# Altium schematic sheet BMS.SchDoc
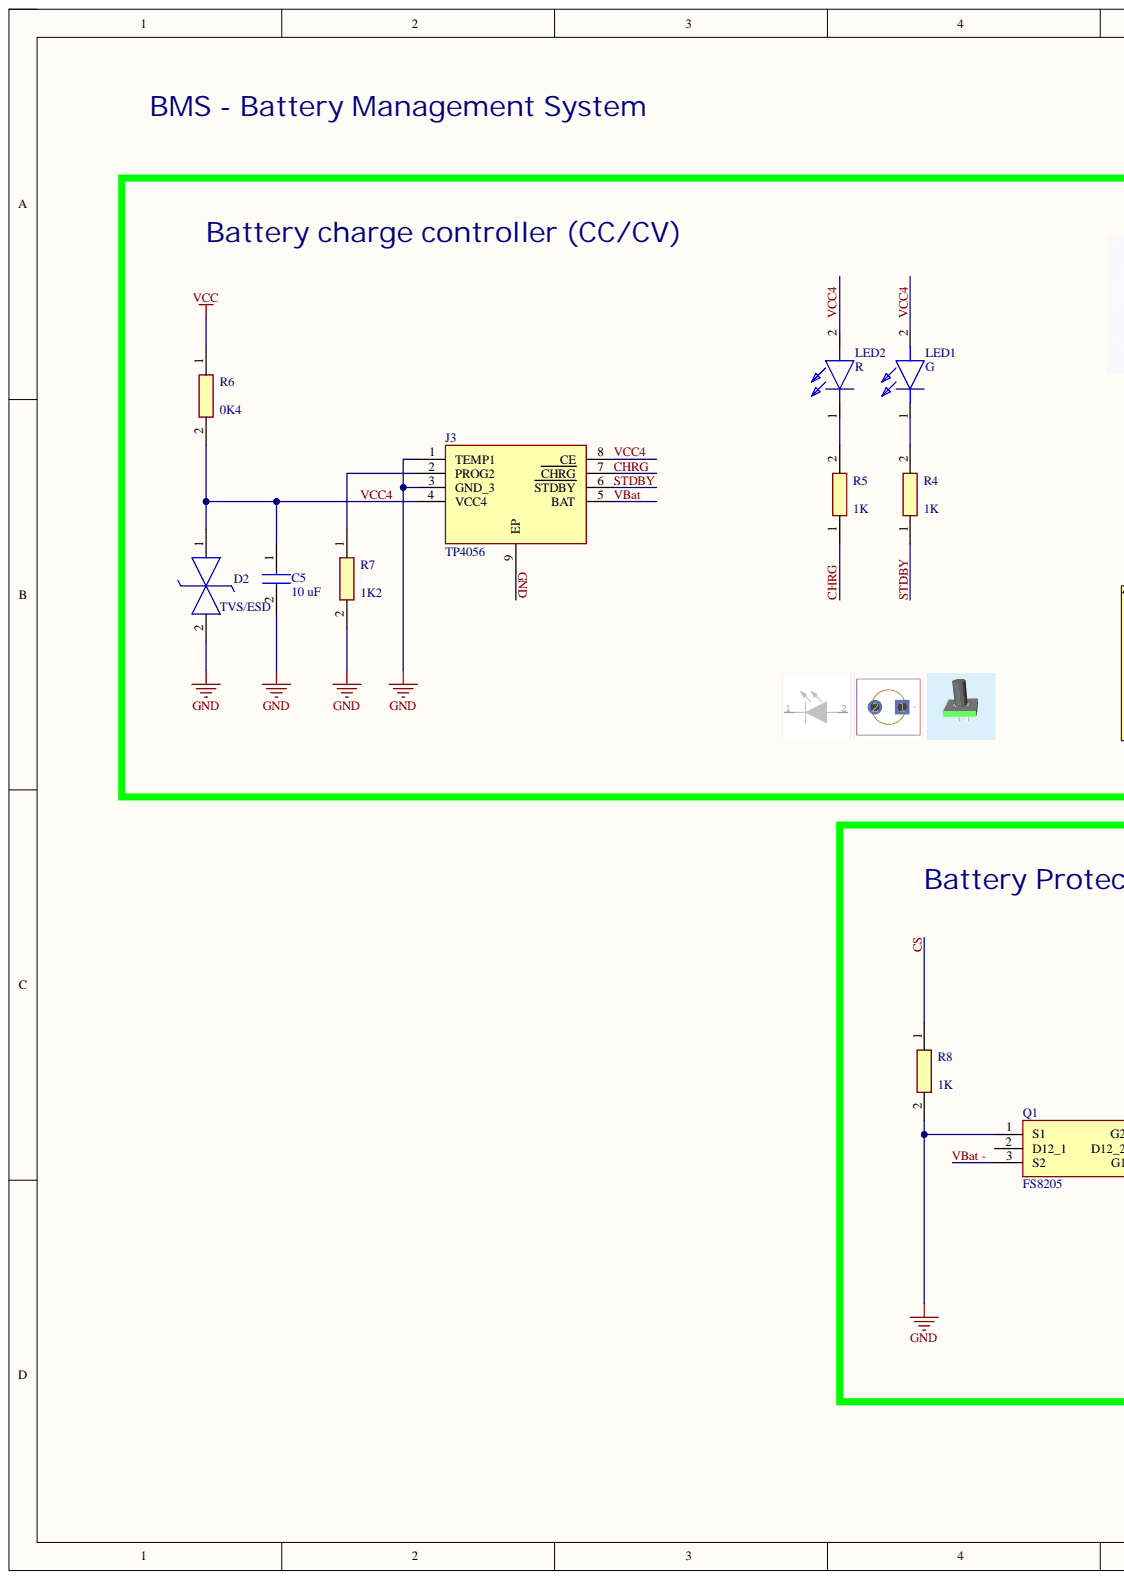
[diagram p0: BMS.SchDoc, left]
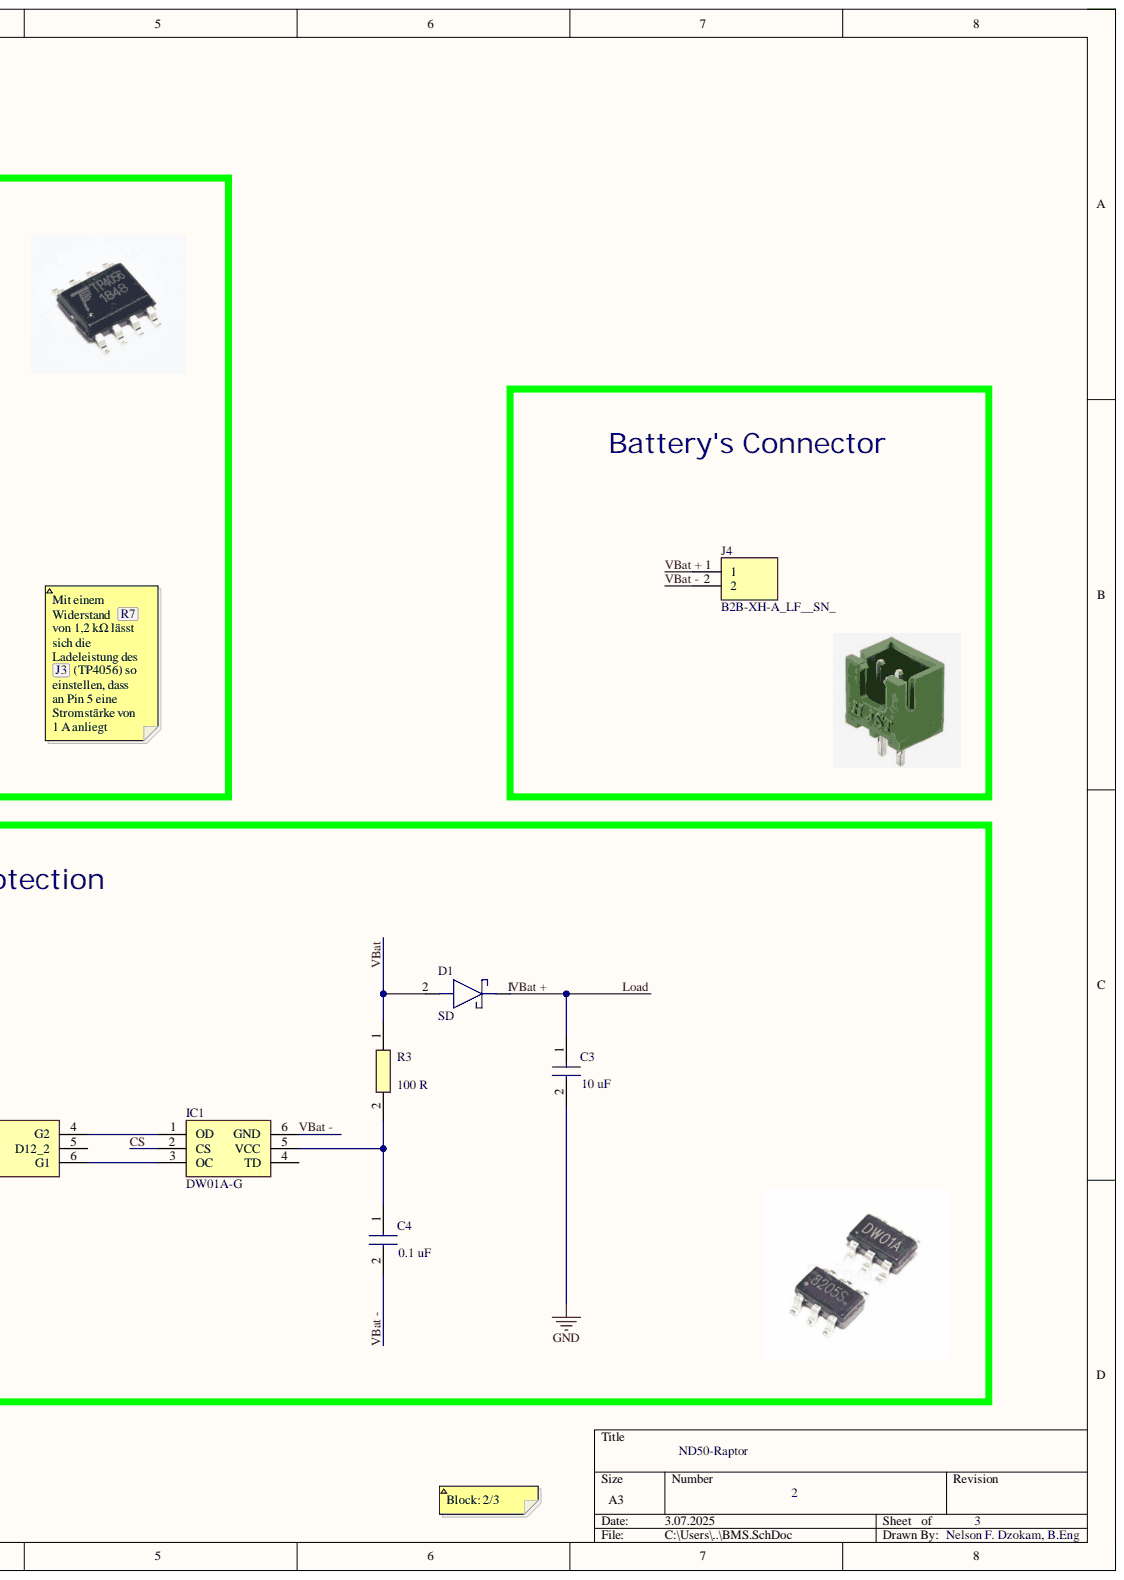
[diagram p1: BMS.SchDoc, right]

## Parts
C3  10 uF  [C0805]
C4  0.1 uF  [C0805]
C5  10 uF  [C0805]
D1  SD  [SODFL4725X110N]
D2  TVS/ESD  [SOD2512X110N]
IC1  DW01A-G  [SOT95P280X135-6N]
J3  TP4056  [SOIC127P600X175-9N]
J4  B2B-XH-A_LF__SN_  [SHDR2W64P0X250_1X2_740X575X700P]
LED1  G  [TLHG4400]
LED2  R  [TLHR4400]
Q1  FS8205  [FS8205]
R3  100 R  [RESC2012X60N]
R4  1K  [RESC2012X60N]
R5  1K  [RESC2012X60N]
R6  0K4  [RESC2012X60N]
R7  1K2  [RESC2012X70N]
R8  1K  [RESC2012X60N]

## Nets
VCC: R6.1
CHRG: J3.7(CHRG) R5.1
CS: IC1.2(CS) R8.1
GND: C3.2 C5.2 D2.2 J3.1(TEMP1) J3.3(GND_3) J3.9(EP) Q1.1(S1) R7.2 R8.2
Load: C3.1 D1.1 J4.1
STDBY: J3.6(STDBY) R4.1
VBat: D1.2 J3.5(BAT) R3.1
VBat -: C4.2 IC1.6(GND) J4.2 Q1.3(S2)
VCC4: C5.1 D2.1 J3.4(VCC4) J3.8(CE) LED1.2 LED2.2 R6.2
NetC4_1: C4.1 IC1.5(VCC) R3.2
NetIC1_1: IC1.1(OD) Q1.4(G2)
NetIC1_3: IC1.3(OC) Q1.6(G1)
NetJ3_2: J3.2(PROG2) R7.1
NetLED1_1: LED1.1 R4.2
NetLED2_1: LED2.1 R5.2

Not connected: IC1 4(TD); Q1 2(D12_1) 5(D12_2)

## Library data of the parts
C3 C5 = C0805C106K7PACTU: Capacitor  C0805C106K7PACTU; Manufacturer_Name: KEMET; Component Kind: Standard; Datasheet Link: https://content.kemet.com/datasheets/KEM_C1006_X5R_SMD.pdf; Height: 1.1mm; Library Name: SamacSys.SchLib; Manufacturer_Part_Number: C0805C106K7PACTU; Mouser Part Number: 80-C0805C106K7P; Mouser Price/Stock: https://www.mouser.com/Search/Refine.aspx?Keyword=80-C0805C106K7P
C4 = C0805C106K7PACTU: Capacitor  08056D104KAT2A; Manufacturer_Name: KEMET; Component Kind: Standard; Datasheet Link: https://content.kemet.com/datasheets/KEM_C1006_X5R_SMD.pdf; Height: 1.1mm; Library Name: SamacSys.SchLib; Manufacturer_Part_Number: C0805C106K7PACTU; Mouser Part Number: 80-C0805C106K7P; Mouser Price/Stock: https://www.mouser.com/Search/Refine.aspx?Keyword=80-C0805C106K7P
D1 = PMEG3020EP-QX: Schottky Diode  PMEG3020EP-QX; Manufacturer_Name: Nexperia; Component Kind: Standard; Datasheet Link: https://assets.nexperia.com/documents/data-sheet/PMEG3020EP-Q.pdf; Height: 1.1mm; Library Name: SamacSys.SchLib; Manufacturer_Part_Number: PMEG3020EP-QX; Mouser Part Number: 771-PMEG3020EP-QX; Mouser Price/Stock: https://www.mouser.co.uk/ProductDetail/Nexperia/PMEG3020EP-QX?qs=doiCPypUmgFzhg9CfUI%2Fgg%3D%3D
D2 = PESD5V0S1BAF: Diode ESD (Bi-directional) PESD5V0S1BAF; Manufacturer_Name: Nexperia; Arrow Part Number: PESD5V0S1BAF; Arrow Price/Stock: https://www.arrow.com/en/products/pesd5v0s1baf/nexperia?utm_currency=USD&region=nac; Component Kind: Standard; Datasheet Link: https://assets.nexperia.com/documents/data-sheet/PESD5V0S1BA.pdf; Height: 1.1mm; Manufacturer_Part_Number: PESD5V0S1BAF; Mouser Part Number: 771-PESD5V0S1BAF; Mouser Price/Stock: https://www.mouser.co.uk/ProductDetail/Nexperia/PESD5V0S1BAF?qs=45Avz0nZxhtk8Fj2r0tKWQ%3D%3D
IC1 = DW01A-G: Integrated Circuit; Manufacturer_Name: Fortune Semiconductor Corporation; Component Kind: Standard; Datasheet Link: http://acoptex.com/uploads/DW01A.pdf; Height: 1.35mm; Manufacturer_Part_Number: DW01A-G
J3 = TP4056: Connector; Manufacturer_Name: NanJing Top Power; Component Kind: Standard; Datasheet Link: https://dlnmh9ip6v2uc.cloudfront.net/datasheets/Prototyping/TP4056.pdf; Height: 1.75mm; Manufacturer_Part_Number: TP4056
J4 = B2B-XH-A_LF__SN_: Connector                                B2B-XH-A_LF__SN_; Manufacturer_Name: JST (JAPAN SOLDERLESS TERMINALS); Manufacturer_Part_Number: B2B-XH-A(LF)(SN); Arrow Part Number: B2B-XH-A(LF)(SN); Arrow Price/Stock: https://www.arrow.com/en/products/b2b-xh-a-lf-sn/jst-manufacturing?utm_currency=USD&region=europe; Component Kind: Standard; Datasheet Link: http://www.jst-mfg.com/product/pdf/eng/eXH.pdf; Height: 7mm; Library Name: SamacSys.SchLib; Mouser Part Number: 306-B2BXHALFSNP; Mouser Price/Stock: https://www.mouser.co.uk/ProductDetail/JST-Commercial/B2B-XH-ALFSN?qs=cdbOS8ANM9DdcSn9qRmfCw%3D%3D
LED1 = TLHG4400: LED                              TLHG4400                      https://www.mouser.de/ProductDetail/Vishay-Semiconductors/TLHG4400?qs=JrSo%252BAIZiDFQF4915wuwPg%3D%3D; Manufacturer_Name: Vishay; Arrow Part Number: TLHG4400; Arrow Price/Stock: https://www.arrow.com/en/products/tlhg4400/vishay?region=europe; Component Kind: Standard; Datasheet Link: https://www.vishay.com/docs/83006/tlhg440.pdf; Height: 6.1mm; Manufacturer_Part_Number: TLHG4400; Mouser Part Number: 78-TLHG4400; Mouser Price/Stock: https://www.mouser.co.uk/ProductDetail/Vishay-Semiconductors/TLHG4400?qs=JrSo%252BAIZiDFQF4915wuwPg%3D%3D
LED2 = TLHR4400: LED                                    TLHR4400                                   https://www.mouser.de/ProductDetail/Vishay-Semiconductors/TLHR4400?qs=W6eMvlWxUPxv3guYUdjVjA%3D%3D&utm_source=digipart&utm_medium=aggregator&utm_campaign=TLHR4400&utm_term=TLHR4400&utm_content=Vishay; Manufacturer_Name: Vishay; Arrow Part Number: TLHR4400; Arrow Price/Stock: https://www.arrow.com/en/products/tlhr4400/vishay; Component Kind: Standard; Datasheet Link: https://www.vishay.com/docs/83006/tlhg440.pdf; Height: 6.1mm; Manufacturer_Part_Number: TLHR4400; Mouser Part Number: 78-TLHR4400; Mouser Price/Stock: https://www.mouser.co.uk/ProductDetail/Vishay-Semiconductors/TLHR4400?qs=W6eMvlWxUPxv3guYUdjVjA%3D%3D
Q1 = FS8205: MOSFET (N-Channel); Manufacturer_Name: Fortune Semiconductor Corporation; Component Kind: Standard; Datasheet Link: https://www.ic-fortune.com/upload/Download/FS8205-DS-19_EN.pdf; Height: 1mm; Manufacturer_Part_Number: FS8205
R3 = CRCW0805100RFKEAHP: Resistor  CRCW0805100RFKEAHP; Manufacturer_Name: Vishay; Arrow Part Number: CRCW0805100RFKEAHP; Arrow Price/Stock: https://www.arrow.com/en/products/crcw0805100rfkeahp/vishay?region=nac; Component Kind: Standard; Datasheet Link: https://componentsearchengine.com/Datasheets/1/CRCW08051R00FKEAHP.pdf; Height: 0.6mm; Manufacturer_Part_Number: CRCW0805100RFKEAHP; Mouser Part Number: 71-CRCW0805100RFKEAH; Mouser Price/Stock: https://www.mouser.co.uk/ProductDetail/Vishay-Dale/CRCW0805100RFKEAHP?qs=k2%2FDWSARqgHN0DmoUSjg4g%3D%3D
R4 R5 R8 = RC0805FR-071KL: Resistor                 RC0805FR-071KL; Manufacturer_Name: KEMET; Arrow Part Number: RC0805FR-071KL; Arrow Price/Stock: https://www.arrow.com/en/products/rc0805fr-071kl/yageo?region=nac; Component Kind: Standard; Datasheet Link: http://www.yageo.com/documents/recent/PYu-RC_Group_51_RoHS_L_10.pdf; Height: 0.6mm; Manufacturer_Part_Number: RC0805FR-071KL; Mouser Part Number: 603-RC0805FR-071KL; Mouser Price/Stock: https://www.mouser.co.uk/ProductDetail/YAGEO/RC0805FR-071KL?qs=1ogmaHw32WJjeGY0DHXakQ%3D%3D
R6 = RC0805FR-071KL: Resistor                 0805W8F400LT5E     400mOhm = 0.4K; Manufacturer_Name: KEMET; Arrow Part Number: RC0805FR-071KL; Arrow Price/Stock: https://www.arrow.com/en/products/rc0805fr-071kl/yageo?region=nac; Component Kind: Standard; Datasheet Link: http://www.yageo.com/documents/recent/PYu-RC_Group_51_RoHS_L_10.pdf; Height: 0.6mm; Manufacturer_Part_Number: RC0805FR-071KL; Mouser Part Number: 603-RC0805FR-071KL; Mouser Price/Stock: https://www.mouser.co.uk/ProductDetail/YAGEO/RC0805FR-071KL?qs=1ogmaHw32WJjeGY0DHXakQ%3D%3D
R7 = ERJ-PB6B1201V: Resistor                            ERJ-PB6B1201V; Manufacturer_Name: Panasonic; Component Kind: Standard; Datasheet Link: https://www.mouser.it/datasheet/2/315/AOA0000C328-1530132.pdf; Height: 0.7mm; Manufacturer_Part_Number: ERJ-PB6B1201V; Mouser Part Number: 667-ERJ-PB6B1201V; Mouser Price/Stock: https://www.mouser.co.uk/ProductDetail/Panasonic/ERJ-PB6B1201V?qs=eFOtW6xYNWEVz95G1v4QyQ%3D%3D
